# Altium schematic sheet Tiresias_misc.SchDoc
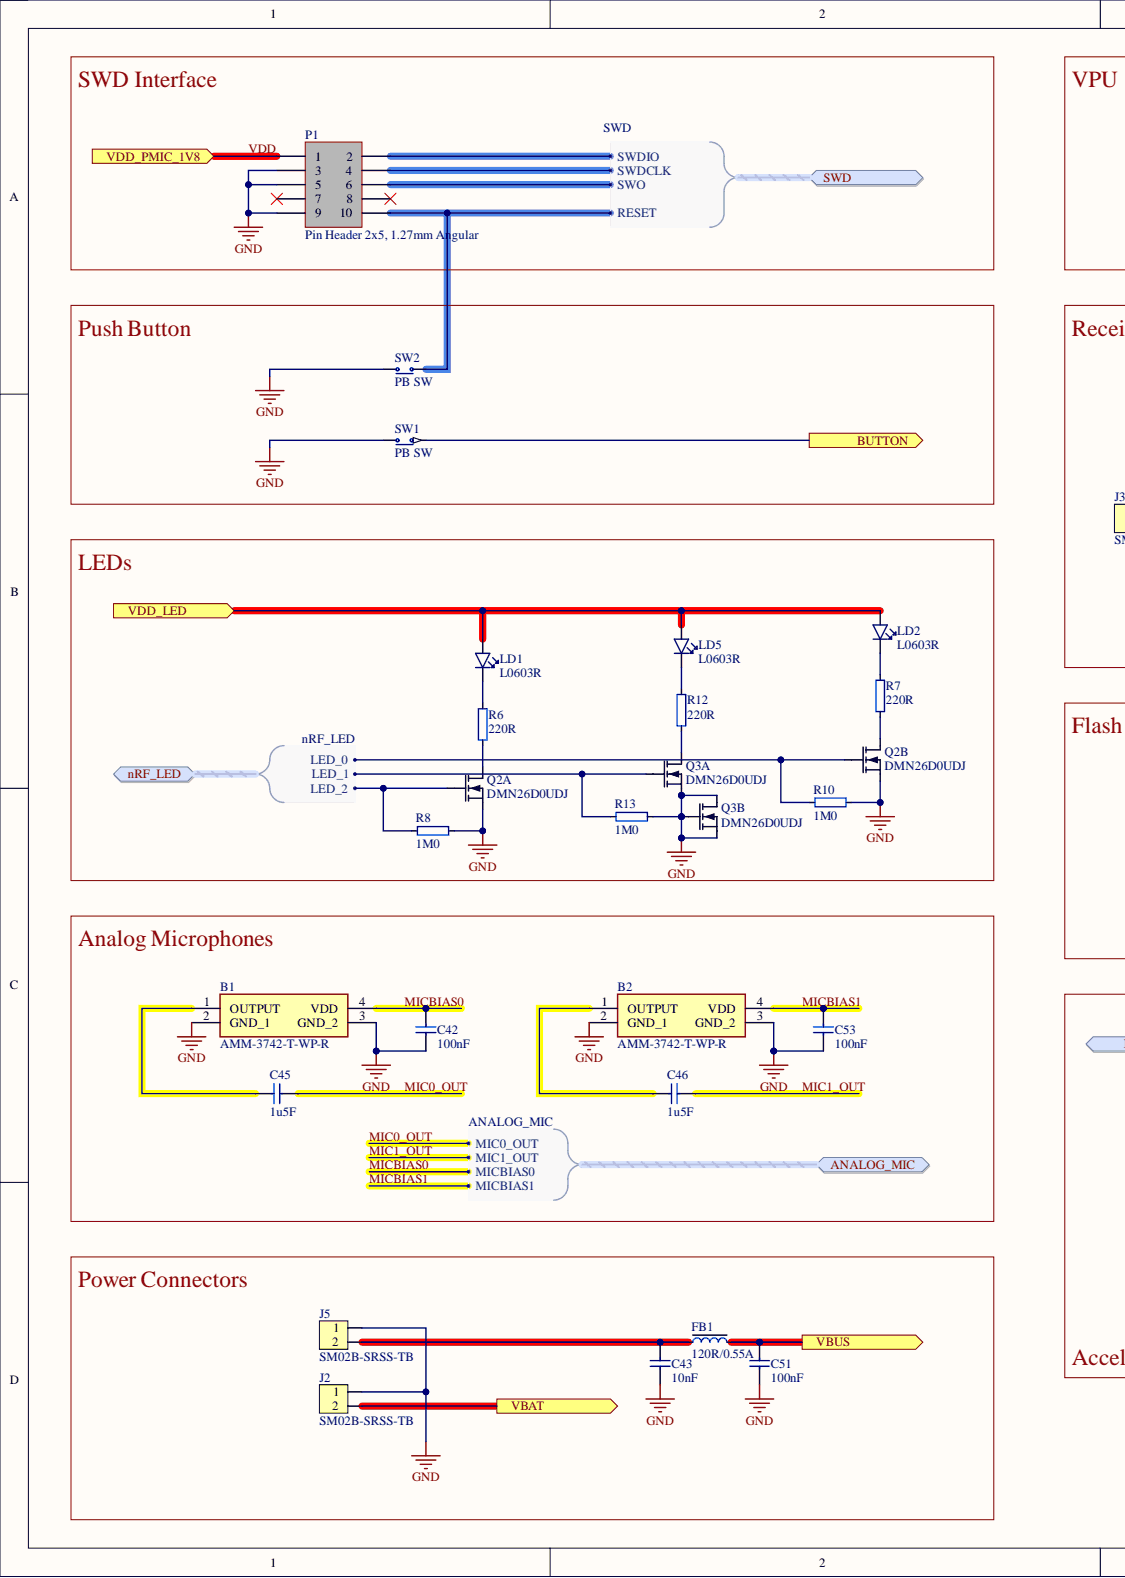
[diagram p0: Tiresias_misc.SchDoc, left]
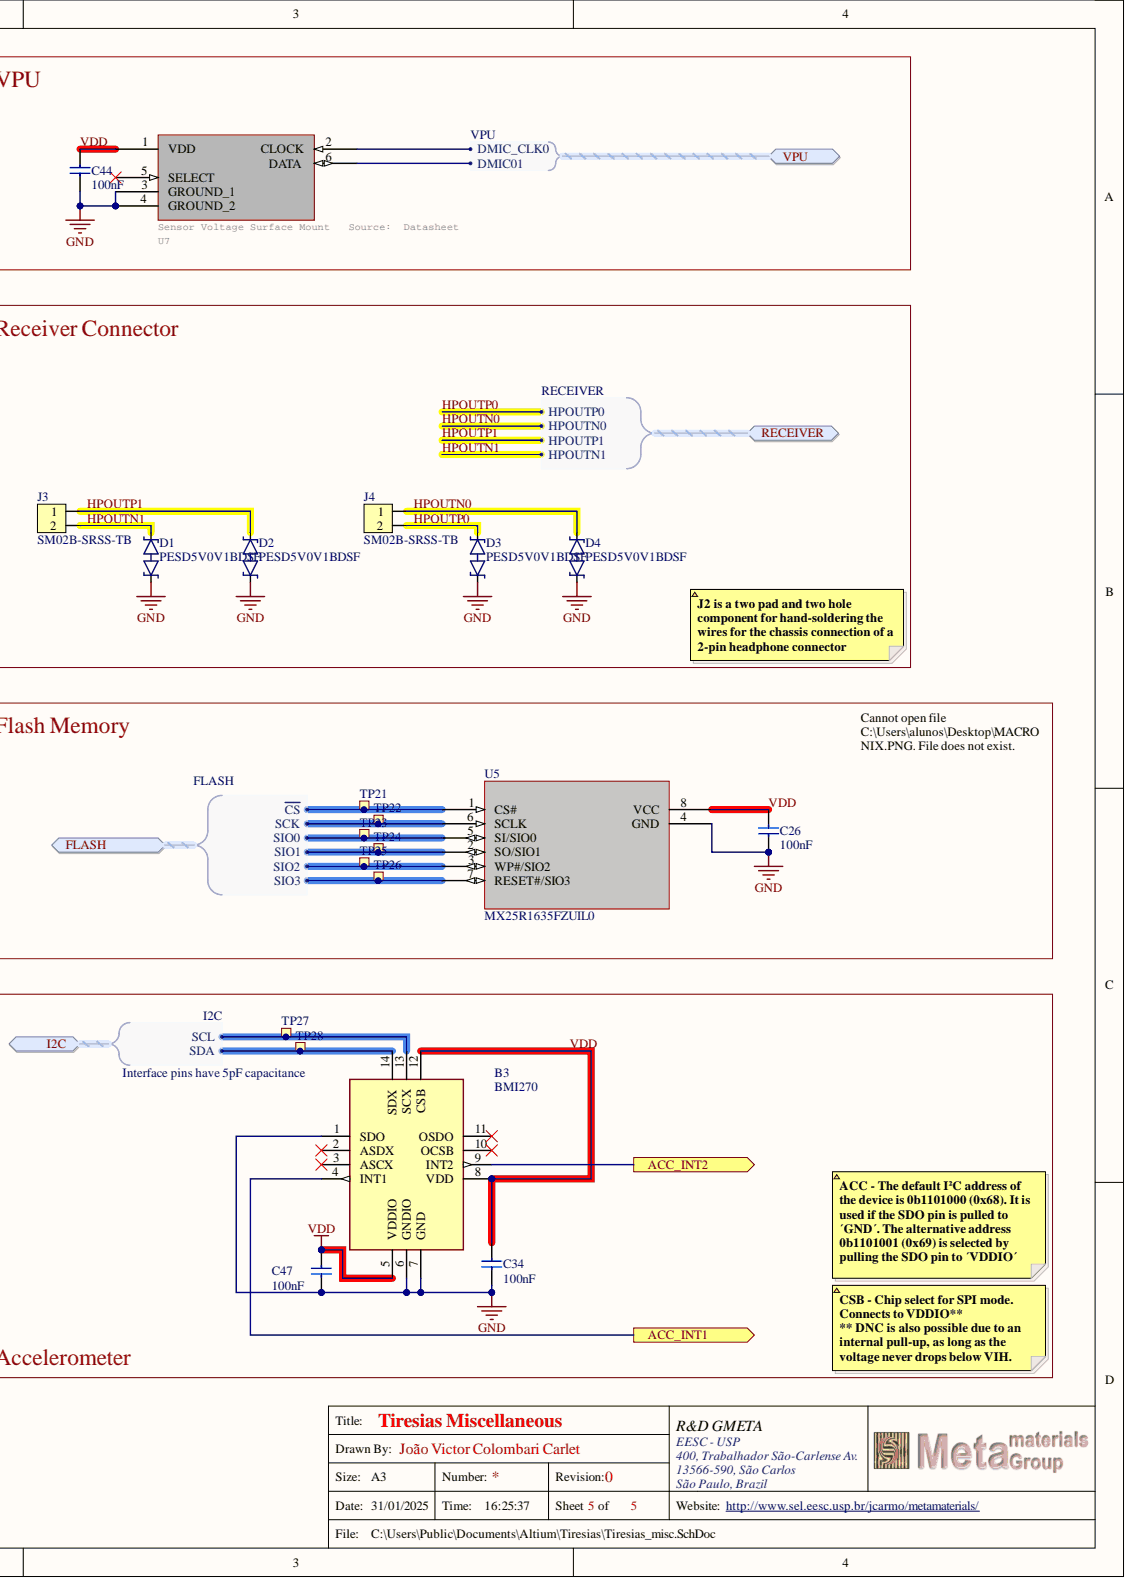
[diagram p1: Tiresias_misc.SchDoc, right]

## Parts
B1  AMM-3742-T-WP-R  [AMM3742TWPR]
B2  AMM-3742-T-WP-R  [AMM3742TWPR]
B3  BMI270  [BMI270]
C26  100nF  [CAPC0603X03L_C]
C34  100nF  [CAPC0603X03L_C]
C42  100nF  [CAPC0603X03L_C]
C43  10nF  [CAPC0603X03L_C]
C44  100nF  [CAPC0603X03L_C]
C45  1u5F  [CAPC1005X04L]
C46  1u5F  [CAPC1005X04L]
C47  100nF  [CAPC0603X03L_C]
C51  100nF  [CAPC0603X03L_C]
C53  100nF  [CAPC0603X03L_C]
D1  PESD5V0V1BDSF  [DFN40P60X30-2N]
D2  PESD5V0V1BDSF  [DFN40P60X30-2N]
D3  PESD5V0V1BDSF  [DFN40P60X30-2N]
D4  PESD5V0V1BDSF  [DFN40P60X30-2N]
FB1  120R/0.55A  [INDC1005X04L]
J2  SM02B-SRSS-TB  [SM02B-SRSS-TB(LF)(SN)]
J3  SM02B-SRSS-TB  [SM02B-SRSS-TB(LF)(SN)]
J4  SM02B-SRSS-TB  [SM02B-SRSS-TB(LF)(SN)]
J5  SM02B-SRSS-TB  [SM02B-SRSS-TB(LF)(SN)]
LD1  L0603R  [LED_0603_R]
LD2  L0603R  [LED_0603_R]
LD5  L0603R  [LED_0603_R]
P1  Pin Header 2x5, 1.27mm Angular  [HDR_2x5-SMD-1.27mm-ANG]
Q2  DMN26D0UDJ  [SOTFL35P100X50-6N]  (parts A,B)
Q3  DMN26D0UDJ  [SOTFL35P100X50-6N]  (parts A,B)
R6  220R  [RESC0603X03L_C]
R7  220R  [RESC0603X03L_C]
R8  1M0  [RESC0603X03L_C]
R10  1M0  [RESC0603X03L_C]
R12  220R  [RESC0603X03L_C]
R13  1M0  [RESC0603X03L_C]
SW1  PB SW  [KLS-TS3401]
SW2  PB SW  [KLS-TS3401]
TP21  Ø1.0mm,SMD  [TP_SMD_D1.0mm]  (value not drawn)  (no BOM)
TP22  Ø1.0mm,SMD  [TP_SMD_D1.0mm]  (value not drawn)  (no BOM)
TP23  Ø1.0mm,SMD  [TP_SMD_D1.0mm]  (value not drawn)  (no BOM)
TP24  Ø1.0mm,SMD  [TP_SMD_D1.0mm]  (value not drawn)  (no BOM)
TP25  Ø1.0mm,SMD  [TP_SMD_D1.0mm]  (value not drawn)  (no BOM)
TP26  Ø1.0mm,SMD  [TP_SMD_D1.0mm]  (value not drawn)  (no BOM)
TP27  Ø1.0mm,SMD  [TP_SMD_D1.0mm]  (value not drawn)  (no BOM)
TP28  Ø1.0mm,SMD  [TP_SMD_D1.0mm]  (value not drawn)  (no BOM)
U5  MX25R1635FZUIL0  [USON-8L-2X3X0P6_MAC-L]
U7  Sensor Voltage Surface Mount   Source:  Datasheet  [V2S200D18]

## Ports
ACC_INT1, ACC_INT2, ANALOG_MIC, ANALOG_MIC.MIC0_OUT, ANALOG_MIC.MIC1_OUT, ANALOG_MIC.MICBIAS0, ANALOG_MIC.MICBIAS1, BUTTON, FLASH, FLASH.SCK, FLASH.SIO0, FLASH.SIO1, FLASH.SIO2, FLASH.SIO3, FLASH.~{CS}, I2C, I2C.SCL, I2C.SDA, nRF_LED, nRF_LED.LED_0, nRF_LED.LED_1, nRF_LED.LED_2, RECEIVER, RECEIVER.HPOUTN0, RECEIVER.HPOUTN1, RECEIVER.HPOUTP0, RECEIVER.HPOUTP1, SWD, SWD.RESET, SWD.SWDCLK, SWD.SWDIO, SWD.SWO, VBAT, VBUS, VDD_LED, VDD_PMIC_1V8, VPU, VPU.DMIC01, VPU.DMIC_CLK0

## Nets
GND: B1.2(GND_1) B1.3(GND_2) B2.2(GND_1) B2.3(GND_2) B3.1(SDO) B3.6(GNDIO) B3.7(GND) C26.1 C34.1 C42.1 C43.1 C44.2 C47.1 C51.1 C53.1 D1.1 D2.1 D3.1 D4.1 J2.1 J5.1 P1.3 P1.5 P1.9 Q2.1 Q2.4 Q3.1 Q3.3 Q3.4 Q3.5 R8.1 R10.1 R13.1 SW1.2 SW2.2 U5.4(GND) U7.3(GROUND_1) U7.4(GROUND_2)
ACC_INT1: B3.4(INT1) ; port ACC_INT1
ACC_INT2: B3.9(INT2) ; port ACC_INT2
BUTTON: SW1.1 ; port BUTTON
HPOUTN0: D4.2 J4.1 ; port RECEIVER
HPOUTN1: D1.2 J3.2 ; port RECEIVER
HPOUTP0: D3.2 J4.2 ; port RECEIVER
HPOUTP1: D2.2 J3.1 ; port RECEIVER
MIC0_OUT: C45.2 ; port ANALOG_MIC
MIC1_OUT: C46.2 ; port ANALOG_MIC
MICBIAS0: B1.4(VDD) C42.2 ; port ANALOG_MIC
MICBIAS1: B2.4(VDD) C53.2 ; port ANALOG_MIC
VBAT: J2.2 ; port VBAT
VBUS: C51.2 FB1.2 ; port VBUS
VDD: B3.5(VDDIO) B3.8(VDD) B3.12(CSB) C26.2 C34.2 C44.1 C47.2 P1.1 U5.8(VCC) U7.1(VDD) ; port VDD_PMIC_1V8
VDD_LED: LD1.2 LD2.2 LD5.2 ; port VDD_LED
NetB1_1: B1.1(OUTPUT) C45.1
NetB2_1: B2.1(OUTPUT) C46.1
NetB3_2: B3.2(ASDX)
NetB3_3: B3.3(ASCX)
NetB3_10: B3.10(OCSB)
NetB3_11: B3.11(OSDO)
NetB3_13: B3.13(SCX) TP27.1 ; port I2C
NetB3_14: B3.14(SDX) TP28.1 ; port I2C
NetC43_2: C43.2 FB1.1 J5.2
NetLD1_1: LD1.1 R6.2
NetLD2_1: LD2.1 R7.2
NetLD5_1: LD5.1 R12.2
NetP1_2: P1.2 ; port SWD
NetP1_4: P1.4 ; port SWD
NetP1_6: P1.6 ; port SWD
NetP1_7: P1.7
NetP1_8: P1.8
NetP1_10: P1.10 SW2.1 ; port SWD
NetQ2_2: Q2.2 R8.2 ; port nRF_LED
NetQ2_3: Q2.3 R7.1
NetQ2_5: Q2.5 R10.2 ; port nRF_LED
NetQ2_6: Q2.6 R6.1
NetQ3_2: Q3.2 R13.2 ; port nRF_LED
NetQ3_6: Q3.6 R12.1
NetTP21_1: TP21.1 U5.1(CS#) ; port FLASH
NetTP22_1: TP22.1 U5.6(SCLK) ; port FLASH
NetTP23_1: TP23.1 U5.5(SI/SIO0) ; port FLASH
NetTP24_1: TP24.1 U5.2(SO/SIO1) ; port FLASH
NetTP25_1: TP25.1 U5.3(WP#/SIO2) ; port FLASH
NetTP26_1: TP26.1 U5.7(RESET#/SIO3) ; port FLASH
NetU7_2: U7.2(CLOCK) ; port VPU
NetU7_5: U7.5(SELECT)
NetU7_6: U7.6(DATA) ; port VPU

## Library data of the parts
B1 B2 = AMM-3742-T-WP-R: Microphones MICROPHONE -42 DB 2 VDC 200 UA 300 OHM,20 Hz ~ 20 kHz Analog Microphone MEMS (Silicon) 1.5 V ~ 3.6 V Omnidirectional (-42dB +/-1dB) Solder Pads; Manufacturer_Name: PUI Audio; Geometry.Height: 1.3mm; Component Kind: Standard; Datasheet Link: https://www.puiaudio.com/media/SpecSheet/AMM-3742-T-WP-R.pdf; Manufacturer_Part_Number: AMM-3742-T-WP-R; Mouser Part Number: 665-AMM-3742-T-WP-R; Mouser Price/Stock: https://www.mouser.co.uk/ProductDetail/PUI-Audio/AMM-3742-T-WP-R?qs=iLbezkQI%252Bsjj6DcgQwGq9A%3D%3D; Library Name: Tiresias_active.SchLib
B3 = BMI270: IMUs - Inertial Measurement Units Smart Ultra-Low Power Inertial Measurement Unit (IMU) for Wearable Applications; Manufacturer_Name: BOSCH; Geometry.Height: 0.87mm; Component Kind: Standard; Datasheet Link: https://www.bosch-sensortec.com/media/boschsensortec/downloads/datasheets/bst-bmi270-ds000.pdf; Manufacturer_Part_Number: BMI270; Mouser Part Number: 262-BMI270; Mouser Price/Stock: https://www.mouser.co.uk/ProductDetail/Bosch-Sensortec/BMI270?qs=u16ybLDytRYIj%252BjQEee88A%3D%3D; Library Name: Tiresias_active.SchLib
C26 C34 C42 C44 C47 C51 C53 = CAP_0201_100nF: Capacitor, NP0, ±2%; Part Number: C0201-100n; Class: SMT; Component Kind: Standard; LatestRevisionDate: 2024/02/20; Package: 0201; Pin Count: 2; Value: 100nF; Library Name: Tiresias_passive.SchLib
C43 = CAP_0201_10nF: Capacitor, NP0, ±2%; Part Number: C0201-100n; Class: SMT; Component Kind: Standard; LatestRevisionDate: 2024/02/20; Package: 0201; Pin Count: 2; Value: 10nF; Library Name: Tiresias_passive.SchLib
C45 C46 = CAP_0402_1u5F: Capacitor, X5R, ±5%; Part Number: C0402-1µ0; Class: SMT; Component Kind: Standard; LatestRevisionDate: 2024/02/20; Package: 0402; Pin Count: 2; Value: 1u5F; Library Name: Tiresias_passive.SchLib
D1-D4 = PESD5V0V1BDSF: Very low capacitance bidirectional ESD protection diode; Manufacturer: Nexperia; Artikkelnr Noca: N.A.; Pad Count: 2; Component Class: Semiconductors; Component Kind: Standard; ComponentLink1Description: Datasheet; ComponentLink1URL: https://assets.nexperia.com/documents/data-sheet/PESD5V0V1BDSF.pdf; ComponentLink2Description: Supplier link; ComponentLink2URL: https://www.digikey.com/product-detail/en/nexperia-usa-inc/PESD5V0V1BDSF-315/1727-1320-1-ND/4386332; Footprint Doc: DSN0603-2; HelpURL: https://www.nexperia.com/products/esd-protection-tvs-filtering-and-signal-conditioning/esd-protection/general-purpose-esd-protection-devices/PESD5V0V1BDSF.html; LatestRevisionDate: 2020-08-23; Manufacturer Part Number: PESD5V0V1BDSF; Note: N.A.; Part Number: PESD5V0V1BDSF; Part number IPS: N.A.; Part number Norautron: N.A.; PartId: 101300179; Published: 2020-08-23; Supplier 1: Digi-Key; Supplier 2: N.A.; Supplier Part Number 1: 1727-1320-1-ND; Supplier Part Number 2: N.A.; Value: PESD5V0V1BDSF; Author: rsk; Library Name: Tiresias_passive.SchLib
FB1 = FB0402-120R@100M-500mA: Ferrite Bead, 120 Ohm @ 100MHz, 500mA, 250 mOhm Max; Manufacturer: Murata; Manufacturer Part Number: BLM15AG121SN1D; Part number IPS: 00000009954; Part number Norautron: N.A.; Artikkelnr Noca: 33029317; Note: Chip EMIFIL Inductor Type Chip Ferrite Bead; Pad Count: 2; Component Class: Inductors; Component Kind: Standard; ComponentLink1Description: Datasheet; ComponentLink1URL: N.A.; ComponentLink2Description: Supplier link; ComponentLink2URL: N.A.; Footprint Doc: 0402; HelpURL: http://search.murata.co.jp/Ceramy/image/img/PDF/ENG/L0110S0100BLM15A_SN.pdf; LatestRevisionDate: 2014-01-10; Part Number: FB0402-120R@100M-500mA; PartId: 100300099; Published: 2011-11-14; Supplier 1: Digi-Key; Supplier 2: Farnell; Supplier Part Number 1: 490-1005-1-ND; Supplier Part Number 2: 151-5760; Value: 120R/0.55A; Author: rsk; Library Name: Tiresias_passive.SchLib
J2-J5 = SM02B-SRSS-TB: 1.0mm pitch connector side entry SMD; Manufacturer: JST Sales America Inc.; Manufacturer Part Number: SM03B-SRSS-TB(LF)(SN); Part Number: SM03B-SRSS-TB; Part number IPS: 00000001785; Artikkelnr Noca: N.A.; Note: JST, Header; Pad Count: 3; Component Class: Connectors; Component Kind: Standard; ComponentLink1Description: Datasheet; ComponentLink1URL: N.A.; ComponentLink2Description: Supplier link; ComponentLink2URL: N.A.; Footprint Doc: SM03B-SRSS-TB; HelpURL: http://www.jst-mfg.com/product/pdf/eng/eSH.pdf; LatestRevisionDate: 2017-10-09; Part number Norautron: N.A.; PartId: 100500605; Published: 2017-10-09; Supplier 1: Cabcon; Supplier 2: Digi-Key; Supplier Part Number 1: SM03B-SRSS-TB(LF)(SN); Supplier Part Number 2: 455-1803-1-ND; Value: SM02B-SRSS-TB; Author: rsk; Library Name: Tiresias_mechanical.SchLib
LD1 LD2 LD5 = LED_0603_RED: LED, SMD, 0603, RED; Manufacturer: Multicomp; Part Number: OVS-0608 RED; Artikkelnr Noca: N.A.; Note: 100 mcd @ 20 mA, Super bright; Pad Count: 2; Component Class: Optoelectronics; Component Kind: Standard; ComponentLink1Description: Datasheet; ComponentLink1URL: N.A.; ComponentLink2Description: Supplier link; ComponentLink2URL: N.A.; Footprint Doc: 0603; HelpURL: http://www.farnell.com/datasheets/1673654.pdf; LatestRevisionDate: 2011-10-28; Manufacturer Part Number: OVS-0608; Part number IPS: N.A.; Part number Norautron: N.A.; PartId: 101400024; Published: 2011-10-28; Supplier 1: Farnell; Supplier 2: N.A.; Supplier Part Number 1: 171-6774; Supplier Part Number 2: N.A.; Value: L0603R; Author: rsk; Library Name: Tiresias_passive.SchLib
P1 = Pin Header 2x5 SMD, 1.27mm, ANG: Pin Header 2x5, 1.27mm (50mil), SMD, Keying Shroud Angular; Manufacturer: W-CON; Manufacturer Part Number: 3132-10MS0BK00R1; Part Number: Pin Header 2x5 SMD, 1.27mm, KS; Part number IPS: 00000009968; Artikkelnr Noca: 55010009; Note: N.A.; Pad Count: 10; Component Class: Connectors; Component Kind: Standard; ComponentLink1Description: Datasheet; ComponentLink1URL: N.A.; ComponentLink2Description: Supplier link; ComponentLink2URL: N.A.; Footprint Doc: HDR_2x5-SMD-1.27mm; HelpURL: \\hizz\pro\app9717\Datasheet\connectors\W-CON\3132-XXMXXBK00XX.pdf; LatestRevisionDate: 2016-04-21; PartId: 100500261; Pin Count: 10; Published: 2016-01-22; Supplier 1: Cabcon; Supplier 2: Samtec; Supplier Part Number 1: 3132-10MS0BK00R1; Supplier Part Number 2: FTSH-105-01-F-DV-K; Value: Pin Header 2x5, 1.27mm Angular; Library Name: Tiresias_mechanical.SchLib; Publisher: Nordic Semiconductor
Q2 Q3 = DMN26D0UDJ-7: MOSFET, Dual N-Channel, 20V, 240mA, 1050mOhm, 300mW; Manufacturer: Diodes Incorporated; Artikkelnr Noca: N.A.; Pad Count: 6; Component Class: Semiconductors; Component Kind: Standard; ComponentLink1Description: Datasheet; ComponentLink1URL: https://www.diodes.com/assets/Datasheets/ds31481.pdf; ComponentLink2Description: Supplier link; ComponentLink2URL: https://www.digikey.com/en/products/detail/diodes-incorporated/DMN26D0UDJ-7/2346781; Footprint Doc: SOT-963; HelpURL: https://www.diodes.com/part/view/DMN26D0UDJ; LatestRevisionDate: 2021-06-03; Manufacturer Part Number: DMN26D0UDJ-7; Note: N.A.; Part Number: DMN26D0UDJ-7; Part number IPS: N.A.; Part number Norautron: N.A.; PartId: 101300183; Published: 2021-06-03; Supplier 1: Digi-Key; Supplier 2: N.A.; Supplier Part Number 1: DMN26D0UDJ-7DICT-ND; Supplier Part Number 2: N.A.; Value: DMN26D0UDJ; Author: rubr; Library Name: Tiresias_active.SchLib
R6 R7 R12 = RES_0201_220R: Resistor, ±1%, 0.05W; Part Number: R0201-220; Part number IPS: 00000000863; Artikkelnr Noca: N.A.; Note: Chip Res 1%; Pad Count: 2; Component Class: Resistors; Component Kind: Standard; ComponentLink1Description: Datasheet; ComponentLink1URL: N.A.; ComponentLink2Description: Supplier link; ComponentLink2URL: N.A.; Footprint Doc: 0201; HelpURL: N.A.; LatestRevisionDate: 2014-11-13; Manufacturer: N.A.; Manufacturer Part Number: N.A.; Part number Norautron: N.A.; PartId: 100100032; Published: 2014-11-13; Supplier 1: N.A.; Supplier 2: N.A.; Supplier Part Number 1: N.A.; Supplier Part Number 2: N.A.; Value: 220R; Author: rsk; Library Name: Tiresias_passive.SchLib
R8 R10 R13 = RES_0201_1M0: Resistor, ±1%, 0.05W; Manufacturer: N.A.; Part Number: R0201-100k; Class: SMT; Component Kind: Standard; ComponentLink1Description: Datasheet; ComponentLink1URL: N.A.; ComponentLink2Description: Supplier link; ComponentLink2URL: N.A.; DigiKey link: 00000001419; JLCpcb link: Chip Res 1%; Latest Revision Date: 2024-04-23; Mouser link: N.A.; Package: 0201; Pin Count: 2; Value: 1M0; Author: rsk; Library Name: Tiresias_passive.SchLib
SW1 SW2 = KLS7-TS3401-2.5-160R: Tactile Switch, SPNO, SMD, 160gf, 4.2x3.3x2.5mm; Manufacturer: KLS; Artikkelnr Noca: N.A.; Pad Count: 4; Component Class: Switches; Component Kind: Standard; ComponentLink1Description: Datasheet; ComponentLink1URL: \\hizz\pro\app9717\Datasheet\switches\KLS\L-KLS7-TS3401-2.5-160-T-B.pdf; ComponentLink2Description: Supplier link; ComponentLink2URL: N.A.; Footprint Doc: KLS-TS3401; HelpURL: N.A.; LatestRevisionDate: 2021-09-22; Manufacturer Part Number: KLS7-TS3401-2.5-160R; Note: N.A.; Part Number: KLS7-TS3401-2.5-160R; Part number IPS: N.A.; Part number Norautron: N.A.; Published: 2021-09-22; Supplier 1: Cabcon; Supplier 2: N.A.; Supplier Part Number 1: KLS7-TS3401-2.5-160R; Supplier Part Number 2: N.A.; Value: PB SW; Author: rubr; Library Name: Tiresias_mechanical.SchLib
TP21-TP28 = TP_SMD_Ø1.0MM: 1.0mm circular SMD testpad; Manufacturer: Nordic Semiconductor; Artikkelnr Noca: N.A.; Pad Count: 1; Component Class: Connectors; ComponentLink1Description: Datasheet; ComponentLink1URL: N.A.; ComponentLink2Description: Supplier link; ComponentLink2URL: N.A.; Footprint Doc: TP_SMD_Ø1.0mm; HelpURL: N.A.; LatestRevisionDate: 2021-03-05; Manufacturer Part Number: N.A.; Note: N.A.; Part Number: TP_SMD_Ø1.0MM; Part number IPS: N.A.; Part number Norautron: N.A.; PartId: 100500475; Published: 2013-06-11; Supplier 1: N.A.; Supplier 2: N.A.; Supplier Part Number 1: N.A.; Supplier Part Number 2: N.A.; Value: Ø1.0mm,SMD; Author: rsk; Library Name: Tiresias_mechanical.SchLib
U5 = MX25R1635FZUIL0: Wide Vcc Range 16M-BIT [x 1/x 2/x 4] CMOS MXSMIO (SERIAL MULTI I/O) FLASH MEMORY; Mfr_Name: Macronix; Component Kind: Standard; RefDes: RefDes; Type: Type; Library Name: Tiresias_logic.SchLib
U7 = V2S200D-1-8: Manufacturer_Name: Knowles; GateName_1: G$1; Component Kind: Standard; DeviceSetName: V2S200D-1-8; Height: 1.03mm; LibraryName: V2S200D-1-8; Manufacturer_Part_Number: V2S200D-1-8; Mouser_Part_Number: 721-V2S200D-1-8; Mouser_Price-Stock: https://www.mouser.co.uk/ProductDetail/Knowles/V2S200D-1-8?qs=ulEaXIWI0c83wNc0KwH8Ig%3D%3D; Package: V2S200D18; Part Number: V2S200D-1-8; Library Name: Tiresias_active.SchLib
